# Altium schematic sheet Communication.SchDoc
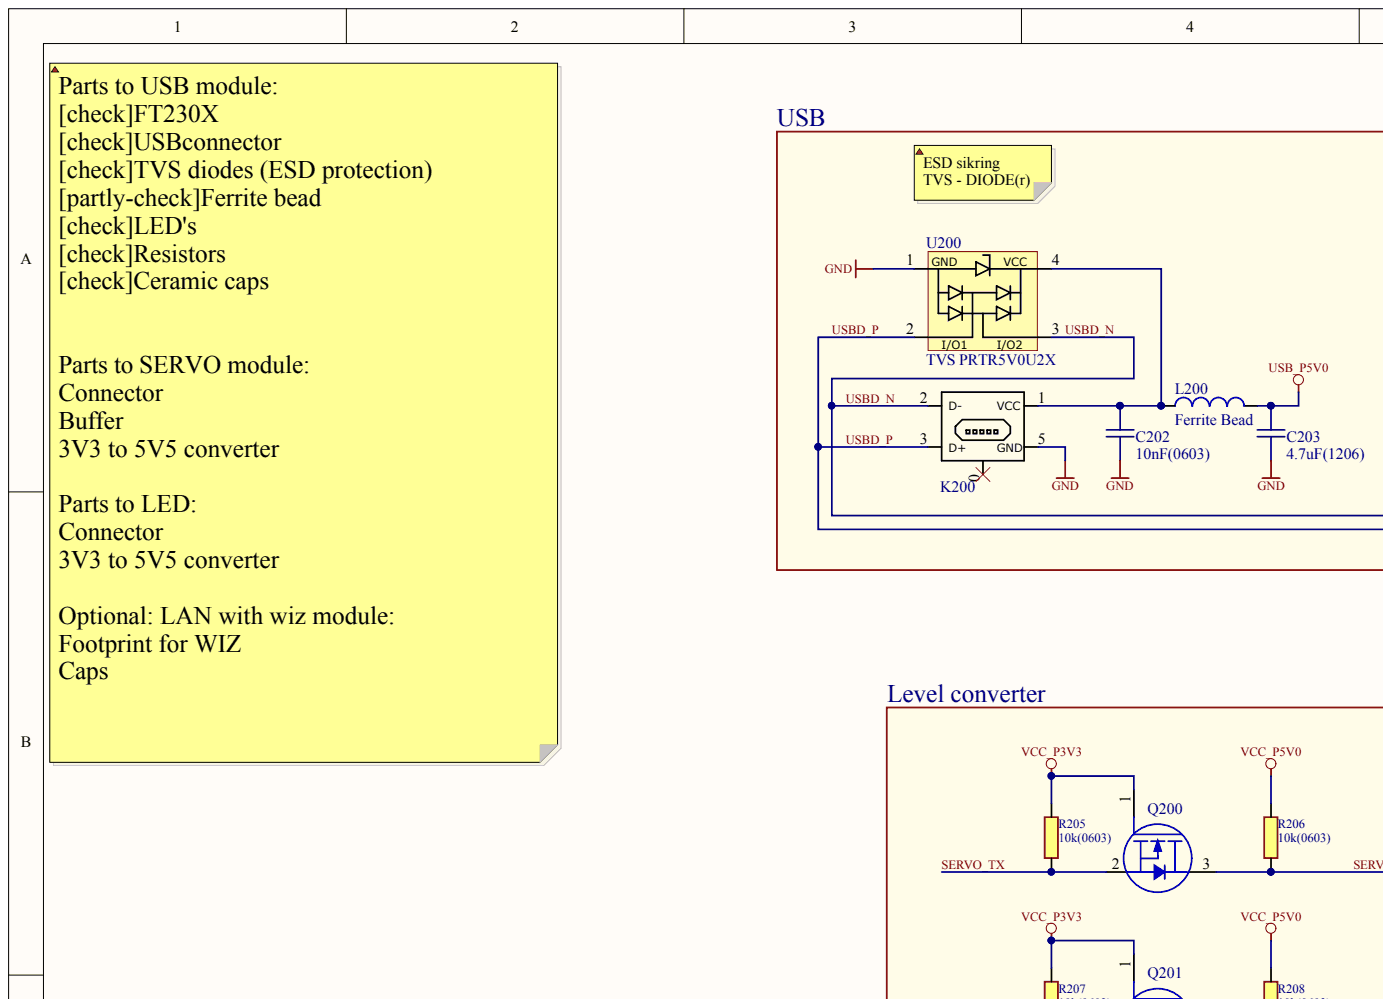
[diagram p0: Communication.SchDoc, top left]
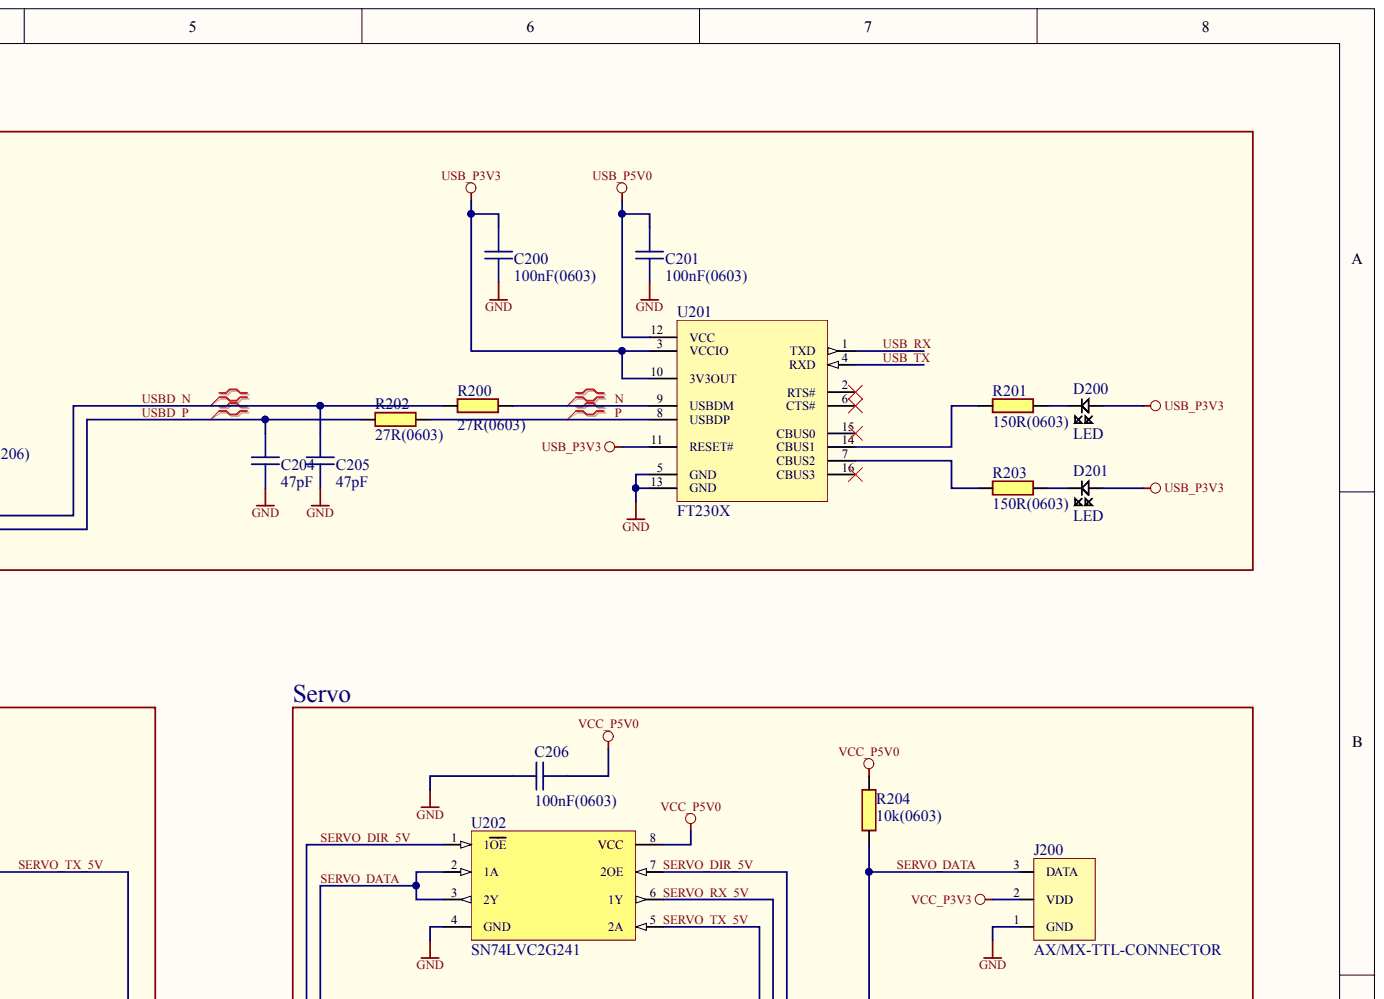
[diagram p1: Communication.SchDoc, top right]
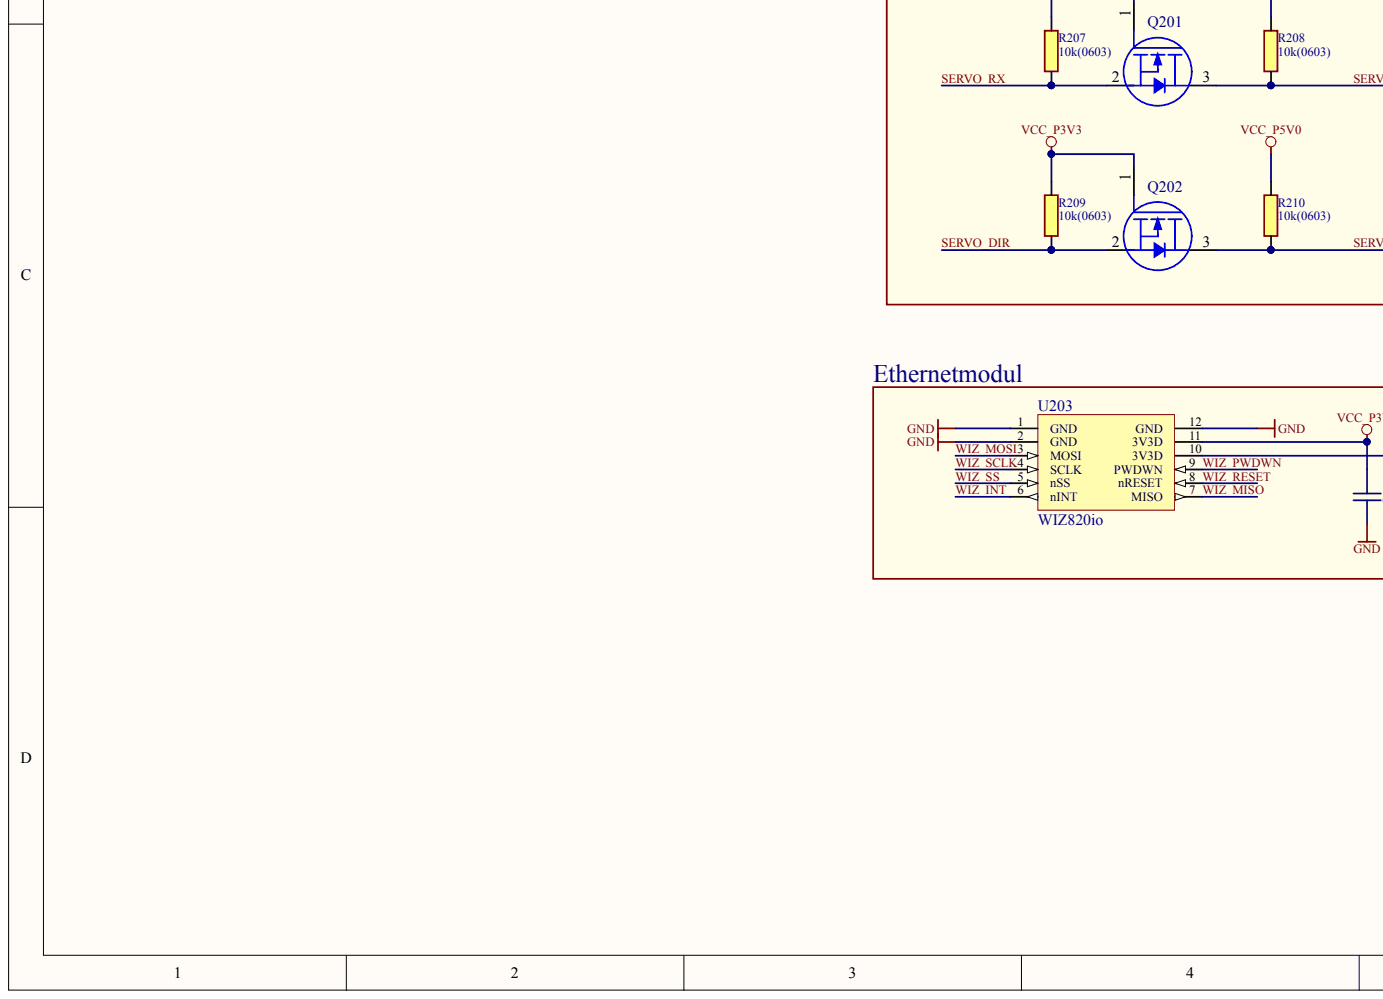
[diagram p2: Communication.SchDoc, bottom left]
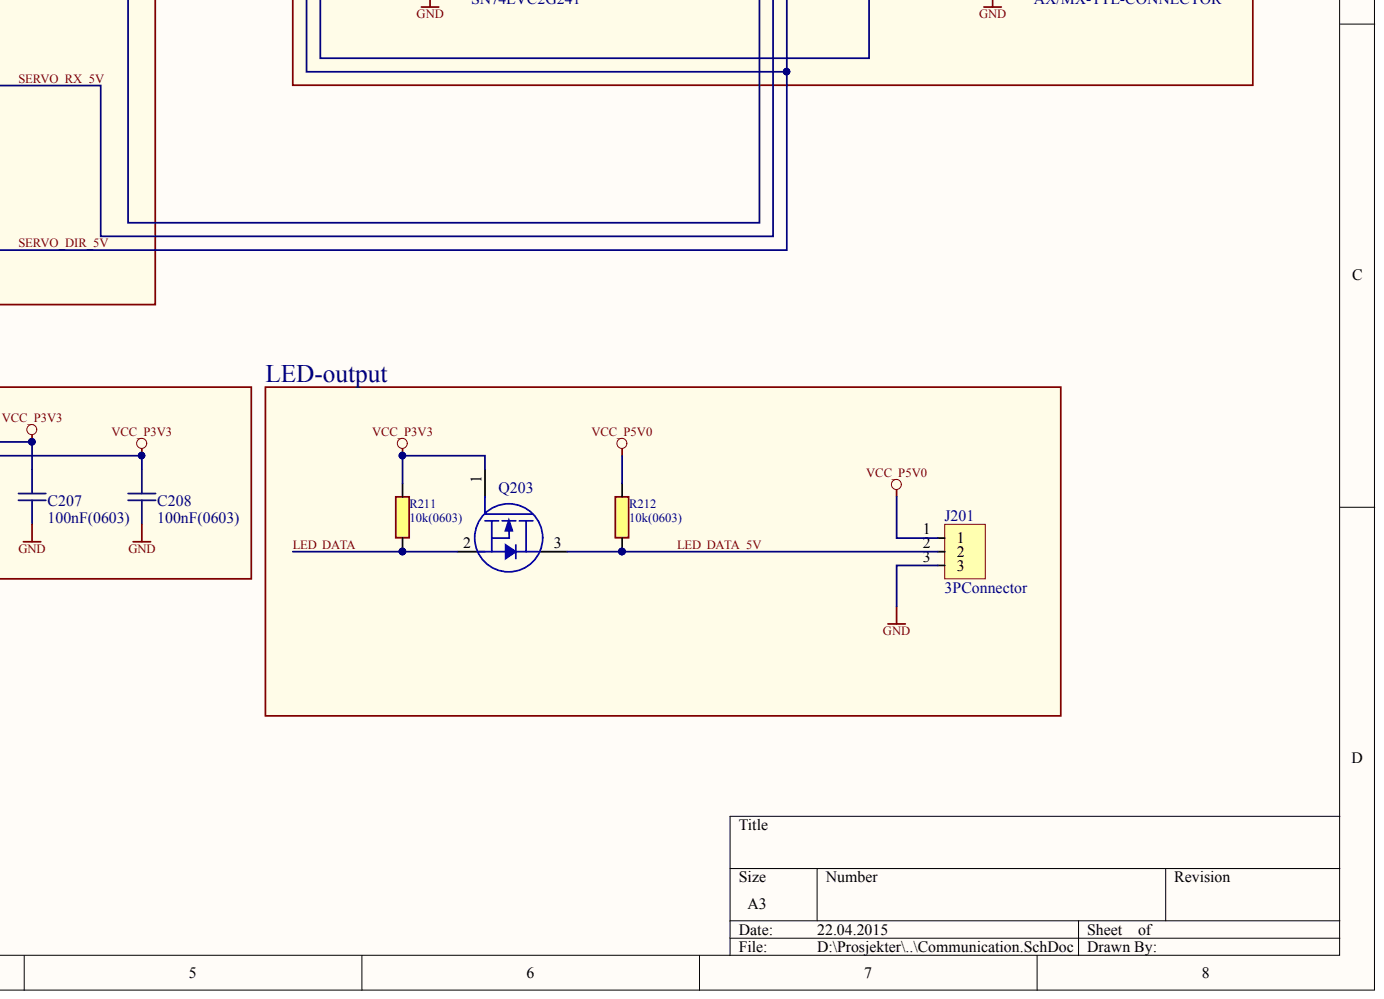
[diagram p3: Communication.SchDoc, bottom right]

## Parts
C200  100nF(0603)  [CAPC0603(1608)55_N]
C201  100nF(0603)  [CAPC0603(1608)55_N]
C202  10nF(0603)  [CAPC0603(1608)55_N]
C203  4.7uF(1206)  [CAPC1206(3216)170_N]
C204  47pF  [CAPC0603(1608)55_N]
C205  47pF  [CAPC0603(1608)55_N]
C206  100nF(0603)  [CAPC0603(1608)55_N]
C207  100nF(0603)  [CAPC0603(1608)55_N]
C208  100nF(0603)  [CAPC0603(1608)55_N]
D200  LED  [I_LEDC0603(1608)_N]
D201  LED  [I_LEDC0603(1608)_N]
J200  AX/MX-TTL-CONNECTOR  [MOLEX_22-03-5035]
J201  3PConnector  [JST_3P]
K200  USB-OTG  [MILL-MAX_897-43-005-00-100001]  (value not drawn)
L200  Ferrite Bead  [0603]
Q200  MOSFET_N_GSD  [SOT323P130X100-3_N]  (value not drawn)
Q201  MOSFET_N_GSD  [SOT323P130X100-3_N]  (value not drawn)
Q202  MOSFET_N_GSD  [SOT323P130X100-3_N]  (value not drawn)
Q203  MOSFET_N_GSD  [SOT323P130X100-3_N]  (value not drawn)
R200  27R(0603)  [RESC0603(1608)_N]
R201  150R(0603)  [RESC0603(1608)_N]
R202  27R(0603)  [RESC0603(1608)_N]
R203  150R(0603)  [RESC0603(1608)_N]
R204  10k(0603)  [RESC0603(1608)_N]
R205  10k(0603)  [RESC0603(1608)_N]
R206  10k(0603)  [RESC0603(1608)_N]
R207  10k(0603)  [RESC0603(1608)_N]
R208  10k(0603)  [RESC0603(1608)_N]
R209  10k(0603)  [RESC0603(1608)_N]
R210  10k(0603)  [RESC0603(1608)_N]
R211  10k(0603)  [RESC0603(1608)_N]
R212  10k(0603)  [RESC0603(1608)_N]
U200  TVS PRTR5V0U2X  [SOT143B-M]
U201  FT230X  [SOP0635P4800-16N]
U202  SN74LVC2G241  [TSOP65P400X130-8N]
U203  WIZ820io  [Wiz820io]

## Nets
GND: C200.1 C201.1 C202.1 C203.1 C204.1 C205.1 C206.1 C207.1 C208.1 J200.1 J201.3 K200.5(GND) U200.1 U201.5(GND) U201.13(GND) U202.4(GND) U203.1(GND) U203.2(GND) U203.12(GND)
USB_P3V3: C200.2 D200.1 D201.1 U201.3(VCCIO) U201.10(3V3OUT) U201.11(RESET#)
USB_P5V0: C201.2 C203.2 L200.2 U201.12(VCC)
VCC_P3V3: C207.2 C208.2 J200.2 Q200.1 Q201.1 Q202.1 Q203.1 R205.1 R207.1 R209.1 R211.1 U203.10(3V3D) U203.11(3V3D)
VCC_P5V0: C206.2 J201.1 R204.2 R206.1 R208.1 R210.1 R212.1 U202.8(VCC)
_N: R200.2 U201.9(USBDM)
_P: R202.2 U201.8(USBDP)
LED_DATA: Q203.2 R211.2
LED_DATA_5V: J201.2 Q203.3 R212.2
SERVO_DATA: J200.3 R204.1 U202.2(1A) U202.3(2Y)
SERVO_DIR: Q202.2 R209.2
SERVO_DIR_5V: Q202.3 R210.2 U202.1(1OE) U202.7(2OE)
SERVO_RX: Q201.2 R207.2
SERVO_RX_5V: Q201.3 R208.2 U202.6(1Y)
SERVO_TX: Q200.2 R205.2
SERVO_TX_5V: Q200.3 R206.2 U202.5(2A)
USB_RX: U201.1(TXD)
USB_TX: U201.4(RXD)
USBD_N: C205.2 K200.2(D-) R200.1 U200.3
USBD_P: C204.2 K200.3(D+) R202.1 U200.2
WIZ_INT: U203.6(nINT)
WIZ_MISO: U203.7(MISO)
WIZ_MOSI: U203.3(MOSI)
WIZ_PWDWN: U203.9(PWDWN)
WIZ_RESET: U203.8(nRESET)
WIZ_SCLK: U203.4(SCLK)
WIZ_SS: U203.5(nSS)
NetC202_2: C202.2 K200.1(VCC) L200.1 U200.4
NetD200_2: D200.2 R201.1
NetD201_2: D201.2 R203.1
NetR201_2: R201.2 U201.14(CBUS1)
NetR203_2: R203.2 U201.7(CBUS2)

Not connected: K200 0; U201 2(RTS#) 6(CTS#) 15(CBUS0) 16(CBUS3)

## Library data of the parts
C200 C201 C206-C208 = 100nF(0603): 100nF 0603 5% X7R 50V; Database Table Name: altium_Kondensatorer; id: 3028; nokkelord: Kondensator, Capacitor, CAP; pakke_opprettet_av: 815; pakketype: 93; pris: 1; Component Kind: Standard; Library Name: OVLib.SVNDbLib; navn: 100nF(0603); pakke_opprettet: 18.02.2015 14:18:13; symbol_opprettet: 14.11.2014 20:19:34; symbol_opprettet_av: 815
C202 = 10nF(0603): 0603 5% NP0 50V; Database Table Name: altium_Kondensatorer; id: 3035; nokkelord: Capacitor Cap Kondis; pakke_opprettet_av: 815; pakketype: 93; pris: 1; Component Kind: Standard; Library Name: OVLib.SVNDbLib; navn: 10nF(0603); pakke_opprettet: 18.02.2015 14:18:13; symbol_opprettet: 14.11.2014 20:19:34; symbol_opprettet_av: 815
C203 = 4.7uF(1206): 1206 chip X7R 50V; Database Table Name: altium_Kondensatorer; id: 2992; nokkelord: Kondensator, capacitor; pakke_opprettet_av: 815; pakketype: 95; pris: 1; produsent: Multikomp; Component Kind: Standard; Library Name: OVLib.SVNDbLib; navn: 4.7uF(1206); pakke_opprettet: 11.02.2015 13:21:22; symbol_opprettet: 14.11.2014 20:19:34; symbol_opprettet_av: 815
C204 C205 = 47pF: 0603 5% NP0 50V; Database Table Name: altium_Kondensatorer; id: 3034; nokkelord: Capacitor Cap Kondis; pakke_opprettet_av: 815; pakketype: 93; pris: 1; Component Kind: Standard; Library Name: OVLib.SVNDbLib; navn: 47pF; pakke_opprettet: 18.02.2015 14:18:13; symbol_opprettet: 14.11.2014 20:19:34; symbol_opprettet_av: 815
D200 D201 = SMD LED Red: SMD; antall: 50; Database Table Name: altium; dybde: 2; hylle: 13; id: 2209; kolonne: 0; lager_type: Fremlager; leverandor: Farnell; leverandor_varenr: 8554641; pakke_opprettet_av: 815; pakketype: 93; pris: 1; produsent: Avago; rom: OV; Component Kind: Standard; Library Name: OVLib.SVNDbLib; navn: SMD LED Red; nokkelord: SMD; pakke_opprettet: 06.07.2014 18:55:44; rad: 0; symbol_opprettet: 06.07.2014 18:59:47; symbol_opprettet_av: 815
J200 = AX/MX-TTL-CONNECTOR: Dynamixel AX/MX-Series servo connector 3 pin TTL version; Manufaturer: MOLEX; Component Kind: Standard; Farnell: 9979620; Library Name: AX_MX-TTL-CONNECTOR.SchLib; MPN: 22-03-5035; Pinout: http://support.robotis.com/en/product/dynamixel/dxl_connector.htm
J201 = JST 3pin: pris pr. stk.; Database Table Name: altium; id: 2226; nokkelord: Connector, Kontakt; pakke_opprettet_av: 815; pakketype: 92; pris: 2; Component Kind: Standard; Library Name: OVLib.SVNDbLib; navn: JST 3pin; pakke_opprettet: 28.06.2014 18:25:12; symbol_opprettet: 28.06.2014 15:33:24; symbol_opprettet_av: 815
K200 = MILL-MAX_897-43-005-00-100001: MINI USB B DEVICE CONNECTOR MOLEX 51387-0571; Manufacturer: Mill-Max Manufacturing Corp.; Component Kind: Standard; Digi-Key: ED90341CT-ND; Farnell: 1925220; Library Name: MILL-MAX_897-43-005-00-100001.SchLib; MPN: 897-43-005-00-100001
L200 = BLM18SG331TN1D: Ferrite Bead 0603; Component Kind: Standard; Digi-Key: 490-3997-1-ND; Farnell: 1515756RL; Library Name: BLM18SG331TN1D.SchLib; MPN: BLM18SG331TN1D
Q200-Q203 = 2N7002: N-Channel MOSFET 300mA; Database Table Name: altium; leverandor: Farnell; leverandor_varenr: 1829184; pakke_opprettet_av: 815; pakketype: 101; pris: 2; symbol_opprettet_av: 774; Component Kind: Standard; Library Name: OVLib.SVNDbLib; navn: 2N7002; pakke_opprettet: 13.11.2014 18:21:11; symbol_opprettet: 11.07.2014 23:17:04
R200 R202 = 3033: 0603 0.1W 1% Thick film resistor; Database Table Name: altium_Motstander; nokkelord: Motstand, Resistor; pakke_opprettet_av: 815; pakketype: 93; pris: 0; symbol_opprettet_av: oystesm; Component Kind: Standard; id: 3033; Library Name: OVLib.SVNDbLib; navn: 27R(0603); pakke_opprettet: 08.07.2014 21:15:30; symbol_opprettet: 08.07.2014 21:16:33
R201 R203 = 3031: 0603 0.1W 1% Thick film resistor; Database Table Name: altium_Motstander; nokkelord: Motstand, Resistor; pakke_opprettet_av: 815; pakketype: 93; pris: 0; produsent: Multikomp; symbol_opprettet_av: oystesm; Component Kind: Standard; id: 3031; Library Name: OVLib.SVNDbLib; navn: 150R(0603); pakke_opprettet: 08.07.2014 21:15:30; symbol_opprettet: 08.07.2014 21:16:33
R204-R212 = 2444: 10k 0603 0.1W 1% Thick film resistor; antall: 100; Database Table Name: altium_Motstander; dybde: 72; hylle: 6; kolonne: 0; lager_type: Fremlager; nokkelord: Resistor Motstand; pakke_opprettet_av: 815; pakketype: 93; rad: -1; rom: OV; symbol_opprettet_av: oystesm; Component Kind: Standard; id: 2444; Library Name: OVLib.SVNDbLib; navn: 10k(0603); pakke_opprettet: 08.07.2014 21:15:30; pris: 0; symbol_opprettet: 08.07.2014 21:16:33
U200 = PRTR5V0U2X: TVS Didode dual PRTR5V0U2X; Manufacturer: NXP; Component Kind: Standard; Digi-Key: 568-4140-1-ND; Farnell Pn: 1524157; Library Name: PRTR5V0U2X.SchLib; MPN: PRTR5V0U2X
U201 = FT230X: IC USB SERIAL BASIC UART 16SSOP; Manufacturer: FTDI, Future Technology Devices International Ltd; MPN: FT230XS-R; Component Kind: Standard; Digi-Key: 768-1135-1-ND; Farnell: 2081321; Library Name: FT230XS.SchLib
U202 = SN74LVC2G241: Dual  Buffer/Driver  With  3-State  Outputs, designed for 1.65-5.5Vcc operation; Manufacturer: Texas Instruments; MPN: SN74LVC2G241DCUR; Component Kind: Standard; Farnell: 2437234; Library Name: SN74LVC2G241.SchLib
U203 = WIZ820io: WIZ820io, Ethernet module with W5200 module onboard and MAG-JACK; Manufacturer: WIZnet; Component Kind: Standard; Digi-Key: 1278-1015-ND; Library Name: WIZ820io.SchLib; MPN: WIZ820IO
